# Altium schematic sheet MINIMUM_SYSTEM.SchDoc
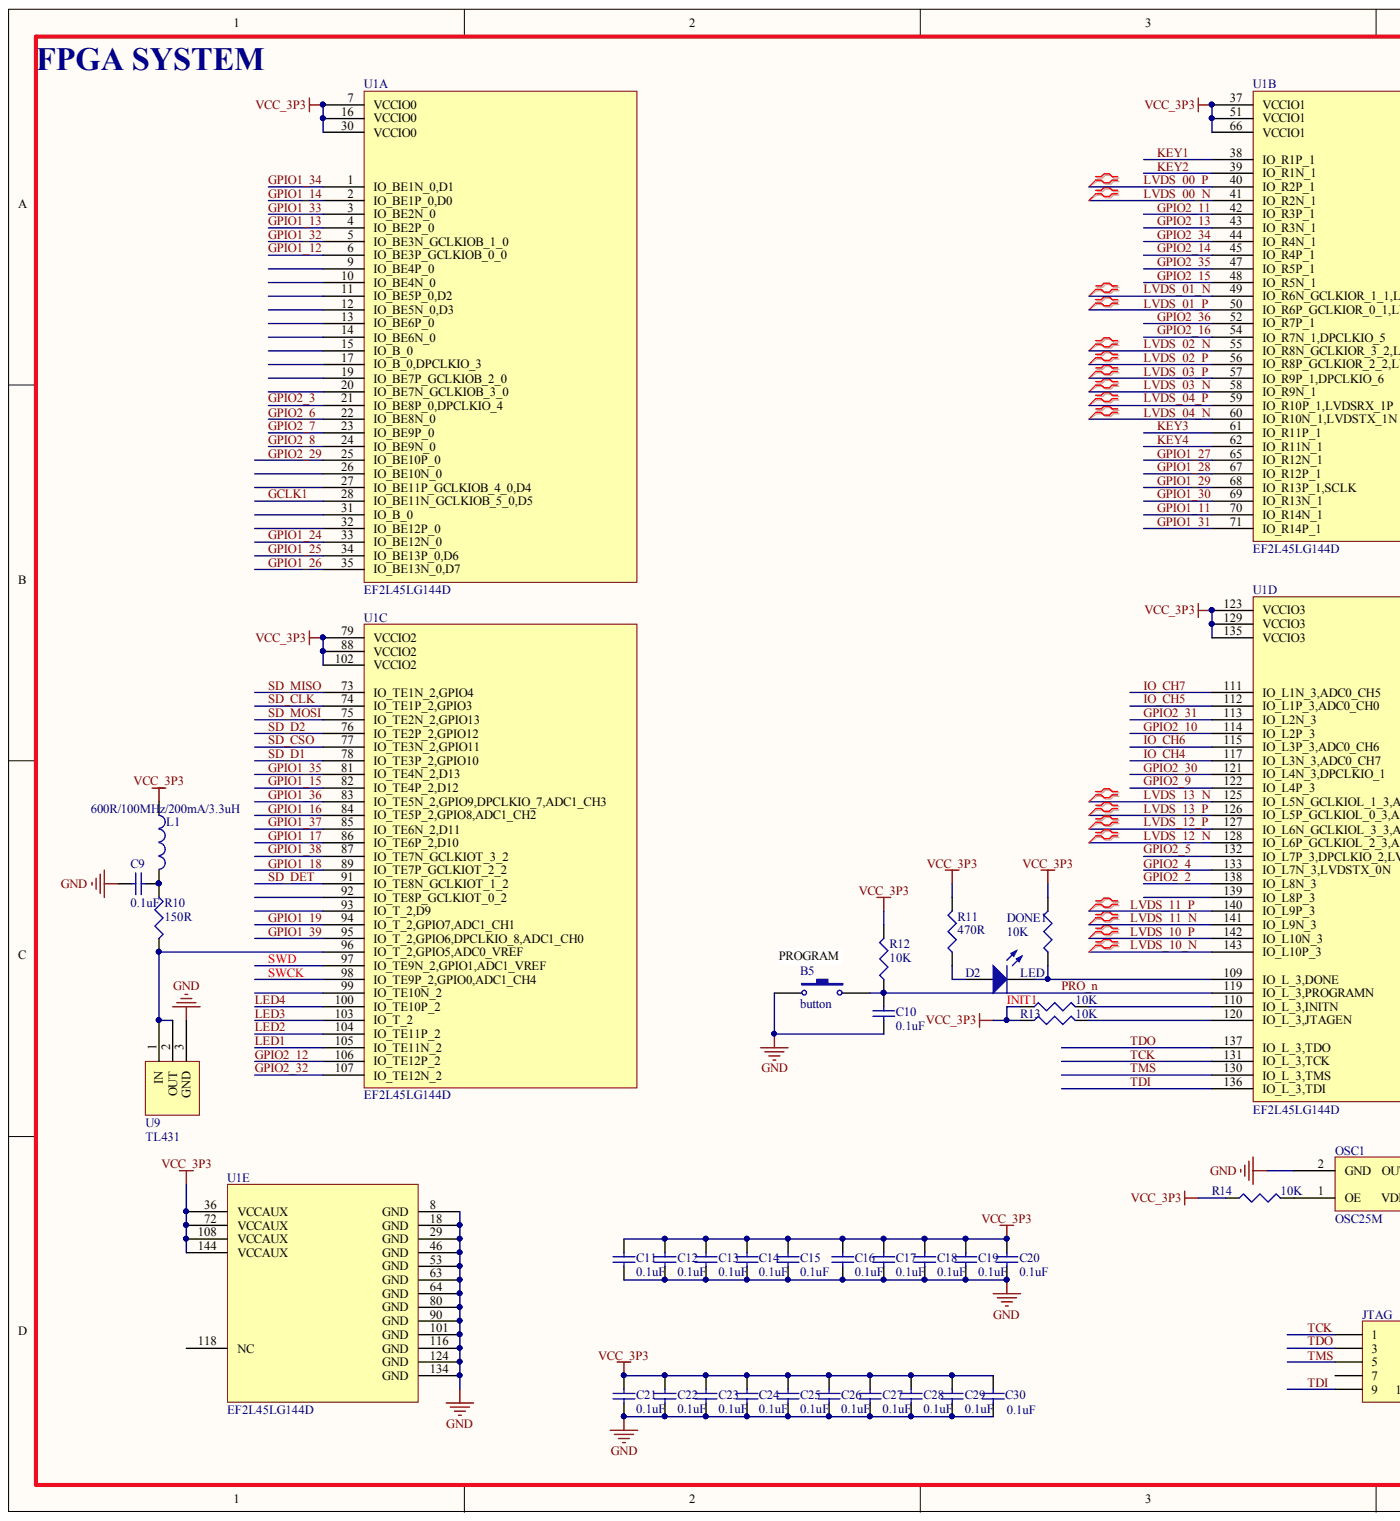
[diagram p0: MINIMUM_SYSTEM.SchDoc, left]
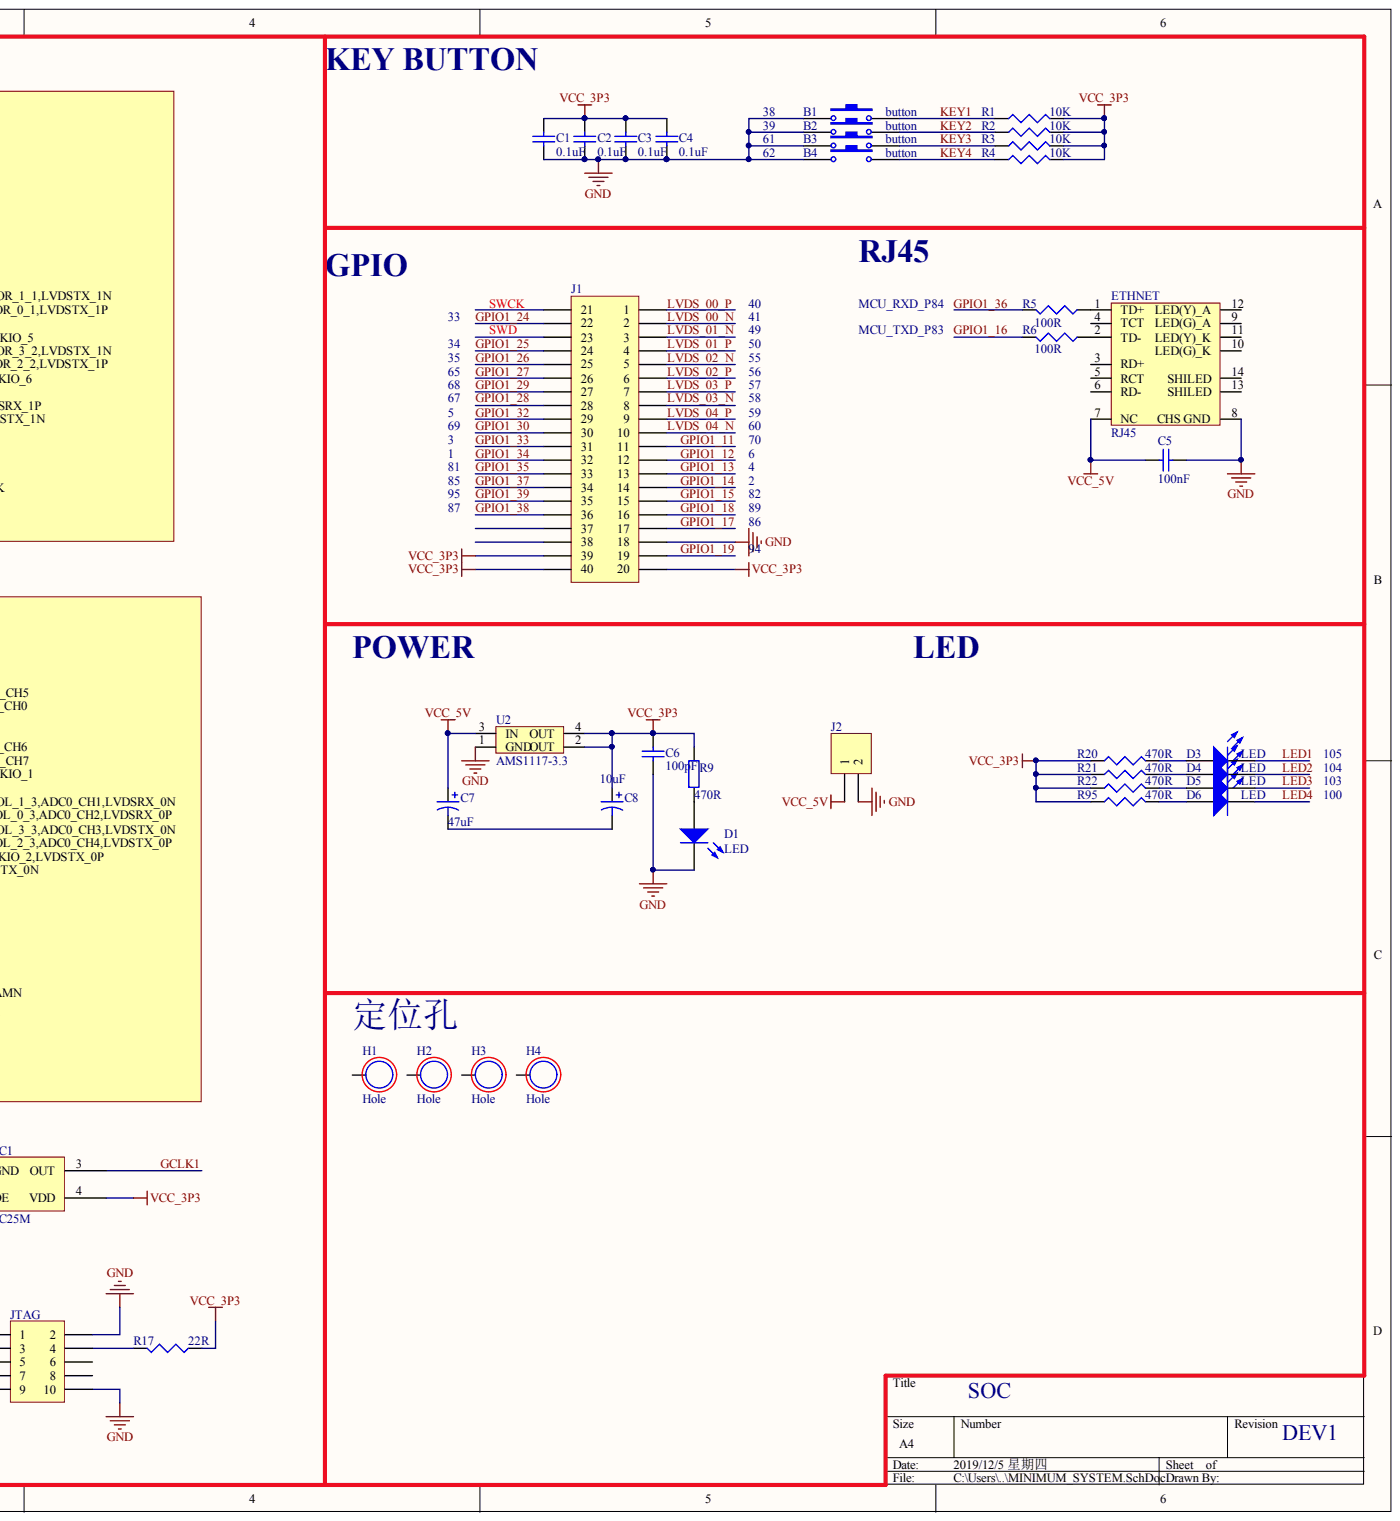
[diagram p1: MINIMUM_SYSTEM.SchDoc, right]

## Parts
B1  button  [KEY_M]
B2  button  [KEY_M]
B3  button  [KEY_M]
B4  button  [KEY_M]
B5  button  [KEY_M]
C1  0.1uF  [0805]
C2  0.1uF  [0805]
C3  0.1uF  [0805]
C4  0.1uF  [0805]
C5  100nF  [0805]
C6  100pF  [0805]
C7  47uF  [1206]
C8  10uF  [1206]
C9  0.1uF  [0805]
C10  0.1uF  [0805]
C11  0.1uF  [0805]
C12  0.1uF  [0805]
C13  0.1uF  [0805]
C14  0.1uF  [0805]
C15  0.1uF  [0805]
C16  0.1uF  [0805]
C17  0.1uF  [0805]
C18  0.1uF  [0805]
C19  0.1uF  [0805]
C20  0.1uF  [0805]
C21  0.1uF  [0805]
C22  0.1uF  [0805]
C23  0.1uF  [0805]
C24  0.1uF  [0805]
C25  0.1uF  [0805]
C26  0.1uF  [0805]
C27  0.1uF  [0805]
C28  0.1uF  [0805]
C29  0.1uF  [0805]
C30  0.1uF  [0805]
D1  LED  [0805]
D2  LED  [0805]
D3  LED  [0805]
D4  LED  [0805]
D5  LED  [0805]
D6  LED  [0805]
DONE1  10K  [0805]
ETHNET  RJ45  [HR911105B]
H1  Hole  [定位孔 Φ3 1 - duplicate]
H2  Hole  [定位孔 Φ3 1 - duplicate]
H3  Hole  [定位孔 Φ3 1 - duplicate]
H4  Hole  [定位孔 Φ3 1 - duplicate]
INIT1  10K  [0805]
J1  HDR2X20  [HDR2X20]  (value not drawn)
J2  Header 2  [HDR1X2]  (value not drawn)
JTAG  Header 5X2  [HDR2X5]  (value not drawn)
L1  600R/100MHz/200mA/3.3uH  [0805]
OSC1  OSC25M  [XTAL7050]
R1  10K  [0805]
R2  10K  [0805]
R3  10K  [0805]
R4  10K  [0805]
R5  100R  [0805]
R6  100R  [0805]
R9  470R  [0805]
R10  150R  [0805]
R11  470R  [0805]
R12  10K  [0805]
R13  10K  [0805]
R14  10K  [0805]
R17  22R  [0805]
R20  470R  [0805]
R21  470R  [0805]
R22  470R  [0805]
R95  470R  [0805]
U1  EF2L45LG144D  [EF2L45LG144B]  (parts A,B,C,D,E)
U2  AMS1117-3.3  [AMS1117]
U9  TL431  [SOT-23]

## Nets
GND: B1.1 B2.1 B3.1 B4.1 B5.2 C1.1 C2.1 C3.1 C4.1 C5.2 C6.1 C9.2 C10.2 C11.2 C12.2 C13.2 C14.2 C15.2 C16.2 C17.2 C18.2 C19.2 C20.2 C21.2 C22.2 C23.2 C24.2 C25.2 C26.2 C27.2 C28.2 C29.2 C30.2 D1.2 ETHNET.8(CHS_GND) J1.18 J2.2 JTAG.2 JTAG.10 OSC1.2(GND) U1.8(GND) U1.18(GND) U1.29(GND) U1.46(GND) U1.53(GND) U1.63(GND) U1.64(GND) U1.80(GND) U1.90(GND) U1.101(GND) U1.116(GND) U1.124(GND) U1.134(GND) U2.1(GND) U9.3
VCC_3P3: C1.2 C2.2 C3.2 C4.2 C6.2 C8.1 C11.1 C12.1 C13.1 C14.1 C15.1 C16.1 C17.1 C18.1 C19.1 C20.1 C21.1 C22.1 C23.1 C24.1 C25.1 C26.1 C27.1 C28.1 C29.1 C30.1 DONE1.2 INIT1.1 J1.20 J1.39 J1.40 L1.1 OSC1.4(VDD) R1.2 R2.2 R3.2 R4.2 R9.2 R11.2 R12.2 R13.1 R14.1 R17.1 R20.1 R21.1 R22.1 R95.1 U1.7(VCCIO0) U1.16(VCCIO0) U1.30(VCCIO0) U1.36(VCCAUX) U1.37(VCCIO1) U1.51(VCCIO1) U1.66(VCCIO1) U1.72(VCCAUX) U1.79(VCCIO2) U1.88(VCCIO2) U1.102(VCCIO2) U1.108(VCCAUX) U1.123(VCCIO3) U1.129(VCCIO3) U1.135(VCCIO3) U1.144(VCCAUX) U2.2(OUT) U2.4(OUT)
VCC_5V: C5.1 C7.1 ETHNET.7(NC) J2.1 U2.3(IN)
GCLK1: OSC1.3(OUT) U1.28(IO_BE11N_GCLKIOB_5_0,D5)
GPIO1_11: J1.11 U1.70(IO_R14N_1)
GPIO1_12: J1.12 U1.6(IO_BE3P_GCLKIOB_0_0)
GPIO1_13: J1.13 U1.4(IO_BE2P_0)
GPIO1_14: J1.14 U1.2(IO_BE1P_0,D0)
GPIO1_15: J1.15 U1.82(IO_TE4P_2,D12)
GPIO1_16: R6.2 U1.84(IO_TE5P_2,GPIO8,ADC1_CH2)
GPIO1_17: J1.17 U1.86(IO_TE6P_2,D10)
GPIO1_18: J1.16 U1.89(IO_TE7P_GCLKIOT_2_2)
GPIO1_19: J1.19 U1.94(IO_T_2,GPIO7,ADC1_CH1)
GPIO1_24: J1.22 U1.33(IO_BE12N_0)
GPIO1_25: J1.24 U1.34(IO_BE13P_0,D6)
GPIO1_26: J1.25 U1.35(IO_BE13N_0,D7)
GPIO1_27: J1.26 U1.65(IO_R12N_1)
GPIO1_28: J1.28 U1.67(IO_R12P_1)
GPIO1_29: J1.27 U1.68(IO_R13P_1,SCLK)
GPIO1_30: J1.30 U1.69(IO_R13N_1)
GPIO1_31: U1.71(IO_R14P_1)
GPIO1_32: J1.29 U1.5(IO_BE3N_GCLKIOB_1_0)
GPIO1_33: J1.31 U1.3(IO_BE2N_0)
GPIO1_34: J1.32 U1.1(IO_BE1N_0,D1)
GPIO1_35: J1.33 U1.81(IO_TE4N_2,D13)
GPIO1_36: R5.2 U1.83(IO_TE5N_2,GPIO9,DPCLKIO_7,ADC1_CH3)
GPIO1_37: J1.34 U1.85(IO_TE6N_2,D11)
GPIO1_38: J1.36 U1.87(IO_TE7N_GCLKIOT_3_2)
GPIO1_39: J1.35 U1.95(IO_T_2,GPIO6,DPCLKIO_8,ADC1_CH0)
GPIO2_2: U1.138(IO_L8N_3)
GPIO2_3: U1.21(IO_BE8P_0,DPCLKIO_4)
GPIO2_4: U1.133(IO_L7N_3,LVDSTX_0N)
GPIO2_5: U1.132(IO_L7P_3,DPCLKIO_2,LVDSTX_0P)
GPIO2_6: U1.22(IO_BE8N_0)
GPIO2_7: U1.23(IO_BE9P_0)
GPIO2_8: U1.24(IO_BE9N_0)
GPIO2_9: U1.122(IO_L4P_3)
GPIO2_10: U1.114(IO_L2P_3)
GPIO2_11: U1.42(IO_R3P_1)
GPIO2_12: U1.106(IO_TE12P_2)
GPIO2_13: U1.43(IO_R3N_1)
GPIO2_14: U1.45(IO_R4P_1)
GPIO2_15: U1.48(IO_R5N_1)
GPIO2_16: U1.54(IO_R7N_1,DPCLKIO_5)
GPIO2_29: U1.25(IO_BE10P_0)
GPIO2_30: U1.121(IO_L4N_3,DPCLKIO_1)
GPIO2_31: U1.113(IO_L2N_3)
GPIO2_32: U1.107(IO_TE12N_2)
GPIO2_34: U1.44(IO_R4N_1)
GPIO2_35: U1.47(IO_R5P_1)
GPIO2_36: U1.52(IO_R7P_1)
IO_CH4: U1.117(IO_L3N_3,ADC0_CH7)
IO_CH5: U1.112(IO_L1P_3,ADC0_CH0)
IO_CH6: U1.115(IO_L3P_3,ADC0_CH6)
IO_CH7: U1.111(IO_L1N_3,ADC0_CH5)
KEY1: B1.2 R1.1 U1.38(IO_R1P_1)
KEY2: B2.2 R2.1 U1.39(IO_R1N_1)
KEY3: B3.2 R3.1 U1.61(IO_R11P_1)
KEY4: B4.2 R4.1 U1.62(IO_R11N_1)
LED1: D3.2 U1.105(IO_TE11N_2)
LED2: D4.2 U1.104(IO_TE11P_2)
LED3: D5.2 U1.103(IO_T_2)
LED4: D6.2 U1.100(IO_TE10P_2)
LVDS_00_N: J1.2 U1.41(IO_R2N_1)
LVDS_00_P: J1.1 U1.40(IO_R2P_1)
LVDS_01_N: J1.3 U1.49(IO_R6N_GCLKIOR_1_1,LVDSTX_1N)
LVDS_01_P: J1.4 U1.50(IO_R6P_GCLKIOR_0_1,LVDSTX_1P)
LVDS_02_N: J1.5 U1.55(IO_R8N_GCLKIOR_3_2,LVDSTX_1N)
LVDS_02_P: J1.6 U1.56(IO_R8P_GCLKIOR_2_2,LVDSTX_1P)
LVDS_03_N: J1.8 U1.58(IO_R9N_1)
LVDS_03_P: J1.7 U1.57(IO_R9P_1,DPCLKIO_6)
LVDS_04_N: J1.10 U1.60(IO_R10N_1,LVDSTX_1N)
LVDS_04_P: J1.9 U1.59(IO_R10P_1,LVDSRX_1P)
LVDS_10_N: U1.143(IO_L10P_3)
LVDS_10_P: U1.142(IO_L10N_3)
LVDS_11_N: U1.141(IO_L9N_3)
LVDS_11_P: U1.140(IO_L9P_3)
LVDS_12_N: U1.128(IO_L6P_GCLKIOL_2_3,ADC0_CH4,LVDSTX_0P)
LVDS_12_P: U1.127(IO_L6N_GCLKIOL_3_3,ADC0_CH3,LVDSTX_0N)
LVDS_13_N: U1.125(IO_L5N_GCLKIOL_1_3,ADC0_CH1,LVDSRX_0N)
LVDS_13_P: U1.126(IO_L5P_GCLKIOL_0_3,ADC0_CH2,LVDSRX_0P)
PRO_N: B5.1 C10.1 R12.1 U1.119(IO_L_3,PROGRAMN)
SD_CLK: U1.74(IO_TE1P_2,GPIO3)
SD_CSO: U1.77(IO_TE3N_2,GPIO11)
SD_D1: U1.78(IO_TE3P_2,GPIO10)
SD_D2: U1.76(IO_TE2P_2,GPIO12)
SD_DET: U1.91(IO_TE8N_GCLKIOT_1_2)
SD_MISO: U1.73(IO_TE1N_2,GPIO4)
SD_MOSI: U1.75(IO_TE2N_2,GPIO13)
SWCK: J1.21 U1.98(IO_TE9P_2,GPIO0,ADC1_CH4)
SWD: J1.23 U1.97(IO_TE9N_2,GPIO1,ADC1_VREF)
TCK: JTAG.1 U1.131(IO_L_3,TCK)
TDI: JTAG.9 U1.136(IO_L_3,TDI)
TDO: JTAG.3 U1.137(IO_L_3,TDO)
TMS: JTAG.5 U1.130(IO_L_3,TMS)
NetC7_2: C7.2 C8.2
NetC9_1: C9.1 L1.2 R10.1
NetD1_1: D1.1 R9.1
NetD2_1: D2.1 R11.1
NetD2_2: D2.2 DONE1.1 U1.109(IO_L_3,DONE)
NetD3_1: D3.1 R20.2
NetD4_1: D4.1 R21.2
NetD5_1: D5.1 R22.2
NetD6_1: D6.1 R95.2
NetETHNET_1: ETHNET.1(TD+) R5.1
NetETHNET_2: ETHNET.2(TD-) R6.1
NetINIT1_2: INIT1.2 U1.110(IO_L_3,INITN)
NetJTAG_4: JTAG.4 R17.2
NetOSC1_1: OSC1.1(OE) R14.2
NetR10_2: R10.2 U1.96(IO_T_2,GPIO5,ADC0_VREF) U9.1 U9.2
NetR13_2: R13.2 U1.120(IO_L_3,JTAGEN)

Not connected: ETHNET 3(RD+) 4(TCT) 5(RCT) 6(RD-) 9(LED(G)_A) 10(LED(G)_K) 11(LED(Y)_K) 12(LED(Y)_A) 13(SHILED) 14(SHILED); H1 1; H2 1; H3 1; H4 1; J1 37 38; JTAG 6 7 8; U1 9(IO_BE4P_0) 10(IO_BE4N_0) 11(IO_BE5P_0,D2) 12(IO_BE5N_0,D3) 13(IO_BE6P_0) 14(IO_BE6N_0) 15(IO_B_0) 17(IO_B_0,DPCLKIO_3) 19(IO_BE7P_GCLKIOB_2_0) 20(IO_BE7N_GCLKIOB_3_0) 26(IO_BE10N_0) 27(IO_BE11P_GCLKIOB_4_0,D4) 31(IO_B_0) 32(IO_BE12P_0) 92(IO_TE8P_GCLKIOT_0_2) 93(IO_T_2,D9) 99(IO_TE10N_2) 118(NC) 139(IO_L8P_3)

## Library data of the parts
B1-B5 = SW-PB: Switch; Component Kind: Standard; Library Name: plm60x_v001.SchLib
C1-C5 C9-C30 = C: Capacitor; Component Kind: Standard
  C1-C4 C9 C10: Library Name: plm60x_v001.SchLib
  C5 C11-C30: Library Name: anl_demo_board.IntLib
C6 = Cap Semi: Capacitor (Semiconductor SIM Model); Component Kind: Standard; LatestRevisionDate: 29-May-2009; LatestRevisionNote: IPC-7351 Footprint Added.; Library Name: Miscellaneous Devices.IntLib; PackageDescription: Chip Capacitor; PackageReference: C1206; Published: 8-Jun-2000; Signal Integrity: Cap Semi; Simulation: CAP; Value: 100pF; Publisher: Altium Limited
C7 C8 = Cap Pol3: Polarized Capacitor (Surface Mount); Component Kind: Standard; LatestRevisionDate: 29-May-2009; LatestRevisionNote: IPC-7351 Footprint Added.; Library Name: Miscellaneous Devices.IntLib; PackageDescription: Chip Capacitor; PackageReference: C0805; Published: 8-Jun-2000; Signal Integrity: Cap Pol; Simulation: CAP; Publisher: Altium Limited
  C7: Value: 47uF
  C8: Value: 10uF
D1 = LED0: Typical INFRARED GaAs LED; Component Kind: Standard; LatestRevisionDate: 08-Jul-2005; LatestRevisionNote: Mech Layer 1 removed.; Library Name: Miscellaneous Devices.IntLib; PackageDescription: LED; 2 Leads; PackageReference: LED-0; Published: 8-Jun-2000; Simulation: LED0; Publisher: Altium Limited
D2-D6 = LED: Typical RED, GREEN, YELLOW, AMBER GaAs LED; Component Kind: Standard; Library Name: anl_download_board_v001.SCHLIB
DONE1 INIT1 R1-R6 R10-R14 R17 R20-R22 R95 = R: Resistor; Component Kind: Standard
  DONE1 INIT1 R10-R13: Library Name: plm60x_v001.SchLib
  R1-R6 R20-R22 R95: Library Name: anl_download_board_v001.SCHLIB
  R14 R17: Library Name: sample.SCHLIB
ETHNET = HR911105: Component Kind: Standard; Description: RJ45; Library Name: MySchLib.SchLib
H1-H4 = LOCATION: 定位孔; Component Kind: Standard; Library Name: MySchLib.SchLib
J1 = Header 20X2_H: Header, 5-Pin, Dual row; Component Kind: Standard; LatestRevisionDate: 17-Jul-2002; LatestRevisionNote: Re-released for DXP Platform.; Signal Integrity: Connector; Library Name: anl_download_board_v001.SCHLIB; Publisher: Altium Limited
J2 = Header 2: Header, 2-Pin; Component Kind: Standard; LatestRevisionDate: 17-Jul-2002; LatestRevisionNote: Re-released for DXP Platform.; Library Name: Miscellaneous Connectors.IntLib; Signal Integrity: Connector; Publisher: Altium Limited
JTAG = Header 5X2: Header, 5-Pin, Dual row; Component Kind: Standard; LatestRevisionDate: 17-Jul-2002; LatestRevisionNote: Re-released for DXP Platform.; Library Name: Miscellaneous Connectors.IntLib; Signal Integrity: Connector; Publisher: Altium Limited
L1 = L: Inductor; Component Kind: Standard; Library Name: plm60x_v001.SchLib
OSC1 = OSC: OSC 4pin; Component Kind: Standard; Library Name: sample.SCHLIB
R9 = Res2: Resistor; Value: 470; Component Kind: Standard; LatestRevisionDate: 17-Jul-2002; LatestRevisionNote: Re-released for DXP Platform.; Library Name: Miscellaneous Devices.IntLib; PackageDescription: Axial Device, Thru-Hole; 2 Leads; 0.4 in Pin Spacing; PackageReference: AXIAL-0.4; Published: 8-Jun-2000; Publisher: Altium Limited
U1 = EF2L4500LG144D: Component Kind: Standard; Library Name: ELF2.SCHLIB
U2 = AMS1117: Component Kind: Standard; Library Name: MySchLib.SchLib
U9 = REF3012: Component Kind: Standard; Library Name: LED.SCHLIB
